# Altium schematic sheet Motion sensor breakout PCB_LSM6DSOXTR.SchDoc("Motion sensor breakout PCB_LSM6DSOXTR")
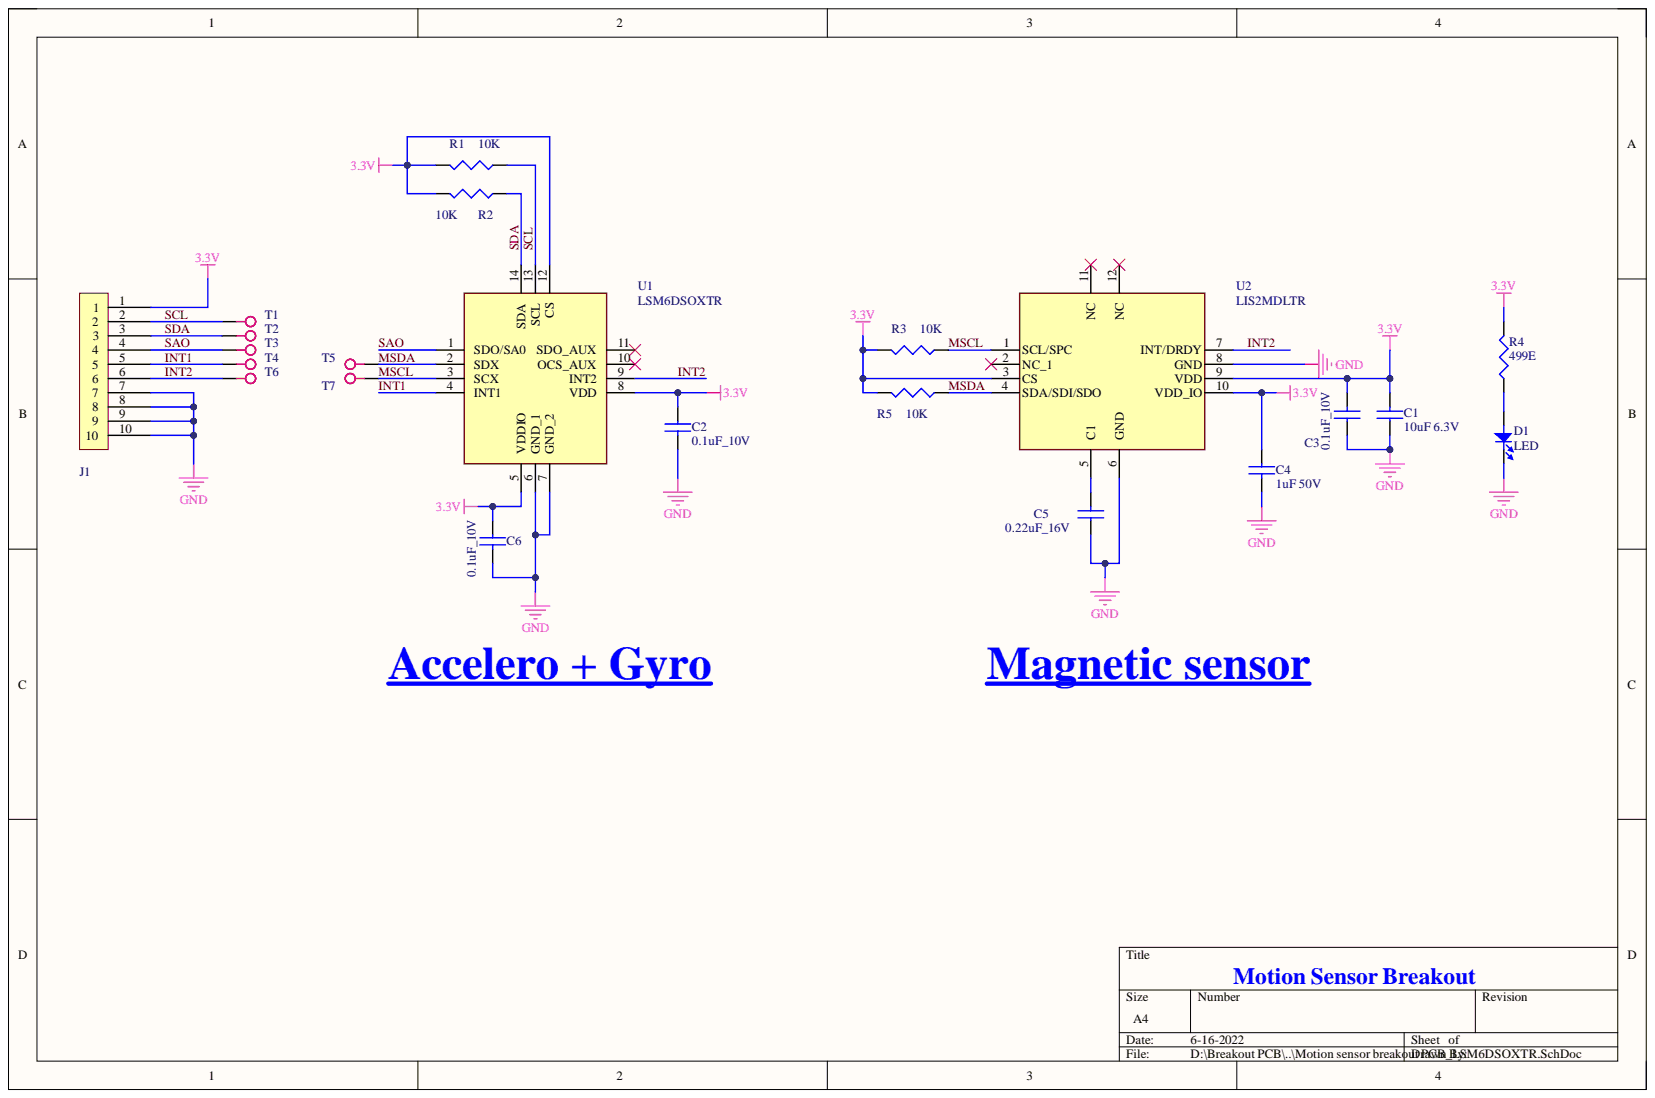
[diagram p0: Motion sensor breakout PCB_LSM6DSOXTR.SchDoc]

## Parts
C1  10uF 6.3V  Capacitance: 10uF  [C0402]
C2  0.1uF_10V  [C0402]
C3  0.1uF_10V  [C0402]
C4  1uF 50V  Voltage Rating: 50V  [C0402]
C5  0.22uF_16V  [C0402]
C6  0.1uF_10V  [C0402]
D1  LED  [LED-0603]
J1  Header 10Pin 0.100" (2.54mm) Through Hole Tin  [10Pin_Header]  (value not drawn)
R1  10K  [R/C0805]
R2  10K  [R/C0805]
R3  10K  [R/C0805]
R4  499E  [R0201]
R5  10K  [R/C0805]
T1  Test point  [Test Point 36-5011-ND]  (value not drawn)
T2  Test point  [Test Point 36-5011-ND]  (value not drawn)
T3  Test point  [Test Point 36-5011-ND]  (value not drawn)
T4  Test point  [Test Point 36-5011-ND]  (value not drawn)
T5  Test point  [Test Point 36-5011-ND]  (value not drawn)
T6  Test point  [Test Point 36-5011-ND]  (value not drawn)
T7  Test point  [Test Point 36-5011-ND]  (value not drawn)
U1  LSM6DSOXTR  [LSM6DSOXTR]
U2  LIS2MDLTR  [LIS2MDLTR]

## Nets
3.3V: C1.2 C2.1 C3.2 C4.1 C6.1 J1.1 R1.2 R2.2 R3.2 R4.2 R5.2 U1.5(VDDIO) U1.8(VDD) U1.12(CS) U2.3(CS) U2.9(VDD) U2.10(VDD_IO)
GND: C1.1 C2.2 C3.1 C4.2 C5.1 C6.2 D1.2 J1.7 J1.8 J1.9 J1.10 U1.6(GND_1) U1.7(GND_2) U2.6(GND) U2.8(GND)
INT1: J1.5 T4.1 U1.4(INT1)
INT2: J1.6 T6.1 U1.9(INT2) U2.7(INT/DRDY)
MSCL: R3.1 T7.1 U1.3(SCX) U2.1(SCL/SPC)
MSDA: R5.1 T5.1 U1.2(SDX) U2.4(SDA/SDI/SDO)
SAO: J1.4 T3.1 U1.1(SDO/SA0)
SCL: J1.2 R1.1 T1.1 U1.13(SCL)
SDA: J1.3 R2.1 T2.1 U1.14(SDA)
NetC5_2: C5.2 U2.5(C1)
NetD1_1: D1.1 R4.1

Not connected: U1 10(OCS_AUX) 11(SDO_AUX); U2 2(NC_1) 11(NC) 12(NC)

## Library data of the parts
C1 = 10uF 6.3V_0402: Cap Ceramic 10uF 6.3V X5R 20% SMD 0402 85C Paper T/R; Manufacturer: Yageo; Manufacturer Part Number: CC0402MRX5R5BB106; Case Code (Imperial): 0402; Case Code (Metric): 1005; Case/Package: 0402; Category: Ceramic Capacitors; Component Kind: Standard; ComponentLink1Description: http://components.arrow.com/part/dataview/0323_07044630?src-supplier=Arrow+Europe; ComponentLink1URL: http://components.arrow.com/part/dataview/0323_07044630?src-supplier=Arrow+Europe; ComponentLink2Description: http://www.yageo.com/documents/recent/UPY-GPHC_X5R_4V-to-50V_25.pdf?src-supplier=Digi-Key; ComponentLink2URL: http://www.yageo.com/documents/recent/UPY-GPHC_X5R_4V-to-50V_25.pdf?src-supplier=Digi-Key; ComponentLink3Description: http://www.yageo.com/documents/recent/UPY-GPHC_X5R_4V-to-50V_25.pdf?src-supplier=Mouser; ComponentLink3URL: http://www.yageo.com/documents/recent/UPY-GPHC_X5R_4V-to-50V_25.pdf?src-supplier=Mouser; ComponentLink4Description: http://www.yageo.com/documents/recent/UPY-GPHC_X5R_4V-to-50V_25.pdf?src-supplier=TTI; ComponentLink4URL: http://www.yageo.com/documents/recent/UPY-GPHC_X5R_4V-to-50V_25.pdf?src-supplier=TTI; ComponentLink5Description: http://www.yageo.com/documents/recent/UPY-GPHC_X5R_4V-to-50V_25.pdf?src-supplier=Rutronik; ComponentLink5URL: http://www.yageo.com/documents/recent/UPY-GPHC_X5R_4V-to-50V_25.pdf?src-supplier=Rutronik; Depth: 0.5mm; Dielectric: X5R; Halogen Free: Halogen Free; Lead Free: Lead Free; Length: 0.039inch; Library Name: Schlib.SchLib; Max Operating Temperature: 85°C; Min Operating Temperature: -55°C; Mount: Surface Mount; Package Quantity: 10000; Packaging: Tape and Reel; Pricing 1: 1=0.27000, 10=0.18600, 50=0.11140, 100=0.09410, 500=0.06438, 1000=0.05695, 2500=0.05447, 5000=0.04952 (USD); Resistor Type: Ceramic; RoHS: Yes; RoHS Compliant: Yes; Stock 1: 95824; Supplier 1: Digi-Key; Supplier Part Number 1: 311-1729-1-ND; Termination: SMD/SMT; Thickness: 0.022inch; Tolerance: 20%; Voltage Rating: 6.3V; Voltage Rating (DC): 6.3V; Weight: 2.3E-05oz; Width: 0.02inch
C2 C3 C6 = 0.1uF 10V 0402: 0402 0.1 uF 10 V ±10 % Tolerance X7R SMT Multilayer Ceramic Capacitor; Manufacturer: Yageo; Manufacturer Part Number: CC0402KRX7R6BB104; Capacitance: 100nF; Tolerance: 10%; Voltage: 10V; Case Code (Imperial): 0402; Case Code (Metric): 1005; Case/Package: 0402; Category: Ceramic Capacitors; Component Kind: Standard; ComponentLink1Description: https://datasheet.ciiva.com/26862/getdatasheetpartid-317655-26862631.pdf?src-supplier=Verical; ComponentLink1URL: https://datasheet.ciiva.com/26862/getdatasheetpartid-317655-26862631.pdf?src-supplier=Verical; ComponentLink2Description: https://datasheet.ciiva.com/144/mlccorderingcode-144363.pdf?src-supplier=Digi-Key; ComponentLink2URL: https://datasheet.ciiva.com/144/mlccorderingcode-144363.pdf?src-supplier=Digi-Key; ComponentLink3Description: https://datasheet.ciiva.com/144/mlccorderingcode-144364.pdf?src-supplier=Digi-Key; ComponentLink3URL: https://datasheet.ciiva.com/144/mlccorderingcode-144364.pdf?src-supplier=Digi-Key; ComponentLink4Description: https://datasheet.ciiva.com/144/mlccorderingcode-144365.pdf?src-supplier=Digi-Key; ComponentLink4URL: https://datasheet.ciiva.com/144/mlccorderingcode-144365.pdf?src-supplier=Digi-Key; ComponentLink5Description: https://datasheet.ciiva.com/11786/0900766b80d84f1d-11786159.pdf?src-supplier=RSComponents; ComponentLink5URL: https://datasheet.ciiva.com/11786/0900766b80d84f1d-11786159.pdf?src-supplier=RSComponents; ComponentLink6Description: https://datasheet.ciiva.com/5426/upy-gphc-x7r-6-3v-to-50v-8-5426469.pdf?src-supplier=Mouser; ComponentLink6URL: https://datasheet.ciiva.com/5426/upy-gphc-x7r-6-3v-to-50v-8-5426469.pdf?src-supplier=Mouser; ComponentLink7Description: https://datasheet.ciiva.com/28450/1489800-28450311.pdf?src-supplier=Element14; ComponentLink7URL: https://datasheet.ciiva.com/28450/1489800-28450311.pdf?src-supplier=Element14; ComponentLink8Description: https://datasheet.ciiva.com/16624/upy-gphc-x7r-6-3v-to-50v-8-16624734.pdf?src-supplier=Avnet+Europe; ComponentLink8URL: https://datasheet.ciiva.com/16624/upy-gphc-x7r-6-3v-to-50v-8-16624734.pdf?src-supplier=Avnet+Europe; Construction: Flat; Depth: 0.5mm; Dielectric: X7R; Halogen Free: Halogen Free; Height: 0.5mm; Lead Free: Lead Free; Length: 1mm; Library Name: Schlib.SchLib; Max Operating Temperature: 125°C; Min Operating Temperature: -55°C; Mount: Surface Mount; Number of Pins: 2; Package Quantity: 1; Packaging: Tape and Reel; Pricing 1: 1=0.10000, 10=0.02700, 50=0.01480, 100=0.01210, 500=0.00866, 1000=0.00680, 2500=0.00618, 5000=0.00569 (USD); Radiation Hardening: No; REACH SVHC: Unknown; Resistor Type: Ceramic; RoHS: Yes; RoHS Compliant: Yes; Series: CC; Stock 1: 2441454; Supplier 1: Digi-Key; Supplier Part Number 1: 311-1345-1-ND; Termination: SMD/SMT; Thickness: 0.022inch; Voltage Rating: 10V; Voltage Rating (DC): 10V; Width: 0.5mm
C4 = 1uF 50V_0402: 0402 1 uF 50 V ±10% Tolerance X5R Multilayer Ceramic Capacitor; Manufacturer: Yageo; Manufacturer Part Number: CC0402KRX5R9BB104; Capacitance: 100nF; Case/Package: 0402; Category: Ceramic Capacitors; Component Kind: Standard; ComponentLink1Description: http://www.yageo.com/documents/recent/UPY-GPHC_X5R_4V-to-50V_23.pdf?src-supplier=Digi-Key; ComponentLink1URL: http://www.yageo.com/documents/recent/UPY-GPHC_X5R_4V-to-50V_23.pdf?src-supplier=Digi-Key; ComponentLink2Description: http://www.yageo.com/documents/recent/UPY-GPHC_X5R_4V-to-50V_25.pdf?src-supplier=Digi-Key; ComponentLink2URL: http://www.yageo.com/documents/recent/UPY-GPHC_X5R_4V-to-50V_25.pdf?src-supplier=Digi-Key; Dielectric: X5R; Halogen Free: Halogen Free; Lead Free: Lead Free; Length: 0.039inch; Library Name: Schlib.SchLib; Max Operating Temperature: 85°C; Min Operating Temperature: -55°C; Mount: Surface Mount; Packaging: Tape and Reel; Pricing 1: 1=0.10000, 10=0.04000, 50=0.02160, 100=0.01770, 500=0.01264, 1000=0.00993, 2500=0.00903, 5000=0.00831 (USD); RoHS: Yes; RoHS Compliant: Yes; Stock 1: 549458; Supplier 1: Digi-Key; Supplier Part Number 1: 587-6278-1-ND; Thickness: 0.022inch; Tolerance: 10%; Voltage Rating (DC): 50V; Width: 0.02inch
C5 = 0.22uF 16V_0402: CAP CER 0.22UF 16V Y5V 0402; Manufacturer: Yageo; Manufacturer Part Number: CC0402ZRY5V7BB224; Capacitance: 220nF; Tolerance: 80%; Case Code (Imperial): 0402; Case Code (Metric): 1005; Case/Package: 0402; Category: Ceramic Capacitors; Component Kind: Standard; ComponentLink1Description: https://datasheet.ciiva.com/144/mlccorderingcode-144941.pdf?src-supplier=Digi-Key; ComponentLink1URL: https://datasheet.ciiva.com/144/mlccorderingcode-144941.pdf?src-supplier=Digi-Key; ComponentLink2Description: https://datasheet.ciiva.com/144/mlccorderingcode-144942.pdf?src-supplier=Digi-Key; ComponentLink2URL: https://datasheet.ciiva.com/144/mlccorderingcode-144942.pdf?src-supplier=Digi-Key; ComponentLink3Description: https://datasheet.ciiva.com/144/mlccorderingcode-144943.pdf?src-supplier=Digi-Key; ComponentLink3URL: https://datasheet.ciiva.com/144/mlccorderingcode-144943.pdf?src-supplier=Digi-Key; Depth: 0.5mm; Dielectric: Y5V; Halogen Free: Halogen Free; Lead Free: Lead Free; Length: 1mm; Library Name: Schlib.SchLib; Max Operating Temperature: 85°C; Min Operating Temperature: -30°C; Mount: Surface Mount; Number of Pins: 2; Package Quantity: 1; Packaging: Tape and Reel; Pricing 1: 1=0.10000, 10=0.06600, 50=0.03600, 100=0.02950, 500=0.02106, 1000=0.01654, 2500=0.01504, 5000=0.01383 (USD); Resistor Type: Ceramic; RoHS: Yes; RoHS Compliant: Yes; Series: CC; Stock 1: 131469; Supplier 1: Digi-Key; Supplier Part Number 1: 311-1350-1-ND; Termination: SMD/SMT; Thickness: 0.022inch; Voltage Rating: 16V; Voltage Rating (DC): 16V; Weight: 2.3E-05oz; Width: 0.5mm
D1 = LED-0603: OSRAM - LO L29K-H2K1-24-Z - SMARTLED 0603 ORANGE; Manufacturer: Osram Opto; Dominant Wavelength: 606nm; Forward Current: 20mA; Forward Voltage: 2.2V; Lens Color: White; Lens Size: 0.8mm; Lens Style: Flat,Diffused,Colorless,Rectangular; Lens Transparency: Diffused; Luminous Flux: 0.025lm; Luminous Intensity: 9mcd; Number of Elements: 1; Reverse Voltage: 12V; Test Current: 2mA; Viewing Angle: 160°; Case Code (Imperial): 0603; Case Code (Metric): 1608; Case/Package: 0603; Category: Uncategorized; Color: Orange; Component Kind: Standard; ComponentLink1Description: https://datasheet.ciiva.com/2112/00077105-0-2112904.pdf?src-supplier=Digi-Key; ComponentLink1URL: https://datasheet.ciiva.com/2112/00077105-0-2112904.pdf?src-supplier=Digi-Key; ComponentLink2Description: https://datasheet.ciiva.com/2112/00077105-0-2112905.pdf?src-supplier=Digi-Key; ComponentLink2URL: https://datasheet.ciiva.com/2112/00077105-0-2112905.pdf?src-supplier=Digi-Key; ComponentLink3Description: https://datasheet.ciiva.com/2112/00077105-0-2112906.pdf?src-supplier=Digi-Key; ComponentLink3URL: https://datasheet.ciiva.com/2112/00077105-0-2112906.pdf?src-supplier=Digi-Key; ComponentLink4Description: https://datasheet.ciiva.com/15198/getdatasheetpartid-693885-15198412.pdf?src-supplier=Verical; ComponentLink4URL: https://datasheet.ciiva.com/15198/getdatasheetpartid-693885-15198412.pdf?src-supplier=Verical; Depth: 0.8mm; Height: 0.65mm; Illumination Color: Orange; Length: 1.3mm; Library Name: Schlib.SchLib; Manufacturer Part Number: LOL29K-H2K1-24-Z; Max Operating Temperature: 100°C; Min Operating Temperature: -40°C; Mount: Surface Mount; Number of LEDs: 1; Number of Pins: 2; Package Quantity: 5000; Packaging: Tape and Reel; Pricing 1: 1=0.460000, 10=0.300000, 100=0.200100, 500=0.138400, 1000=0.115330, 2000=0.103800 (USD); Radiation Hardening: No; RoHS: Yes; RoHS Compliant: Yes; Series: SmartLED; Stock 1: 11369; Supplier 1: Digi-Key; Supplier Part Number 1: 475-2740-1-ND; Width: 0.8mm
J1 = 10Pin_Header: HARWIN - M20-7821046 - Board-To-Board Connector, M20 Series, Through Hole, Receptacle, 10, 2.54 mm, Tin Plated Contacts; Connector Type: Receptacle,Socket; Contact Resistance: 20mR; Current Rating: 3A; Hole Diameter: 0.8mm; Insulation Resistance: 1MR; Mating Cycles: 50; Number of Contacts: 10; Case/Package: SIL; Category: Headers and Wire Housings; Color: Black; Component Kind: Standard; ComponentLink1Description: https://datasheet.ciiva.com/26899/getdatasheetpartid-559226-26899379.pdf?src-supplier=Verical; ComponentLink1URL: https://datasheet.ciiva.com/26899/getdatasheetpartid-559226-26899379.pdf?src-supplier=Verical; ComponentLink2Description: https://datasheet.ciiva.com/11753/0900766b80dc1d2b-11753869.pdf?src-supplier=RSComponents; ComponentLink2URL: https://datasheet.ciiva.com/11753/0900766b80dc1d2b-11753869.pdf?src-supplier=RSComponents; ComponentLink3Description: https://datasheet.ciiva.com/6785/m20-782-6785605.pdf?src-supplier=Digi-Key; ComponentLink3URL: https://datasheet.ciiva.com/6785/m20-782-6785605.pdf?src-supplier=Digi-Key; ComponentLink4Description: https://datasheet.ciiva.com/10151/m20-782-10151366.pdf?src-supplier=Avnet; ComponentLink4URL: https://datasheet.ciiva.com/10151/m20-782-10151366.pdf?src-supplier=Avnet; ComponentLink5Description: https://datasheet.ciiva.com/16732/m20-782-16732152.pdf?src-supplier=Avnet+Europe; ComponentLink5URL: https://datasheet.ciiva.com/16732/m20-782-16732152.pdf?src-supplier=Avnet+Europe; Contact Gender: Female; Contact Material: Bronze; Contact Plating: Tin; Depth: 2.5mm; Gender: Receptacle; Housing Color: Black; Housing Material: Polyamide; Lead Pitch: 2.54mm; Length: 25.8mm; Library Name: Schlib.SchLib; Manufacturer: Harwin; Manufacturer Part Number: M20-7821046; Max Current Rating: 3A; Max Operating Temperature: 105°C; Min Operating Temperature: -40°C; Mount: Through Hole; Number of Positions: 10; Number of Rows: 1; Orientation: Straight; Package Quantity: 23; Packaging: Rail/Tube; Pitch: 2.54mm; Pricing 1: 1=1.29000, 10=1.13300, 100=0.97770, 500=0.79992, 1000=0.71104, 5000=0.62216, 10000=0.59105 (USD); Radiation Hardening: No; REACH SVHC: No SVHC; RoHS: Yes; Stock 1: 1280; Supplier 1: Digi-Key; Supplier Part Number 1: 952-1847-ND; Termination: Solder
R1-R3 R5 = 10K-0402: 10K - Surge Chip Resistor Automotive Grade Thick Film 0402 10kOhm 5% Paper T/R; Manufacturer: Yageo; Manufacturer Part Number: SR0402JR-7W10KL; Category: Chip SMD Resistor; Component Kind: Standard; Library Name: Schlib.SchLib; Pricing 1: 1=0.42000, 10=0.35700, 100=0.13950, 1000=0.05841, 2500=0.05354, 5000=0.04868 (USD); RoHS: Yes; Stock 1: 19140; Supplier 1: Digi-Key; Supplier Part Number 1: YAG6158CT-ND
R4 = 499R_0201: Res Thick Film 0201 499 Ohm 1% 1/20W ±200ppm/°C Molded SMD Paper T/R RC0201FR-07499RL (2); Manufacturer: Yageo; Max Power Dissipation: 50mW; Resistance: 499R; Case Code (Imperial): 0201; Case Code (Metric): 0603; Case/Package: 0201; Category: Chip SMD Resistors; Component Kind: Standard; ComponentLink1Description: http://components.arrow.com/part/dataview/0323_03311567?src-supplier=Arrow+Europe; ComponentLink1URL: http://components.arrow.com/part/dataview/0323_03311567?src-supplier=Arrow+Europe; ComponentLink2Description: http://www.yageo.com/documents/recent/PYu-RC_Group_51_RoHS_L_9.pdf?src-supplier=Digi-Key; ComponentLink2URL: http://www.yageo.com/documents/recent/PYu-RC_Group_51_RoHS_L_9.pdf?src-supplier=Digi-Key; ComponentLink3Description: http://www.yageo.com/documents/recent/PYu-RC_Group_51_RoHS_L_10.pdf?src-supplier=Digi-Key; ComponentLink3URL: http://www.yageo.com/documents/recent/PYu-RC_Group_51_RoHS_L_10.pdf?src-supplier=Digi-Key; Depth: 0.3mm; Features: Moisture Resistant; Height: 0.01inch; Lead Free: Lead Free; Length: 0.024inch; Library Name: Schlib.SchLib; Manufacturer Part Number: RC0201FR-07499RL; Max Operating Temperature: 125°C; Military Standard: Not; Min Operating Temperature: -55°C; Number of Terminations: 2; Packaging: Tape and Reel; Pricing 1: 1=0.10000, 10=0.04200, 100=0.01700, 1000=0.00765, 2500=0.00664, 5000=0.00548 (USD); Resistor Type: Thick Film; RoHS: Yes; RoHS Compliant: Yes; Stock 1: 1488; Supplier 1: Digi-Key; Supplier Part Number 1: YAG2700CT-ND; Temperature Coefficient: 200ppm/°C; Tolerance: 1%; Width: 0.012inch
T1-T7 = Test point: PC TEST POINT MULTIPURPOSE BLACK  36-5011-ND; Component Kind: Standard; Library Name: Schlib.SchLib
U1 = LSM6DSOXTR: IMUs - Inertial Measurement Units iNEMO inertial module Machine Learning Core, Finite State Machine & advanced Dig; Component Kind: Standard; Library Name: Schlib.SchLib; Supplier 1: Newark; Supplier Part Number 1: 99AC0350
U2 = LIS2MDLTR: Board Mount Hall Effect/Magnetic Sensors Magnetic sensor, digital output, 50 gauss magnetic field dynamic range, ultra-lo; Component Kind: Standard; Library Name: Schlib.SchLib; Supplier 1: Newark; Supplier Part Number 1: 07AH6648
